# Altium schematic sheet Analog.SchDoc(Analog)
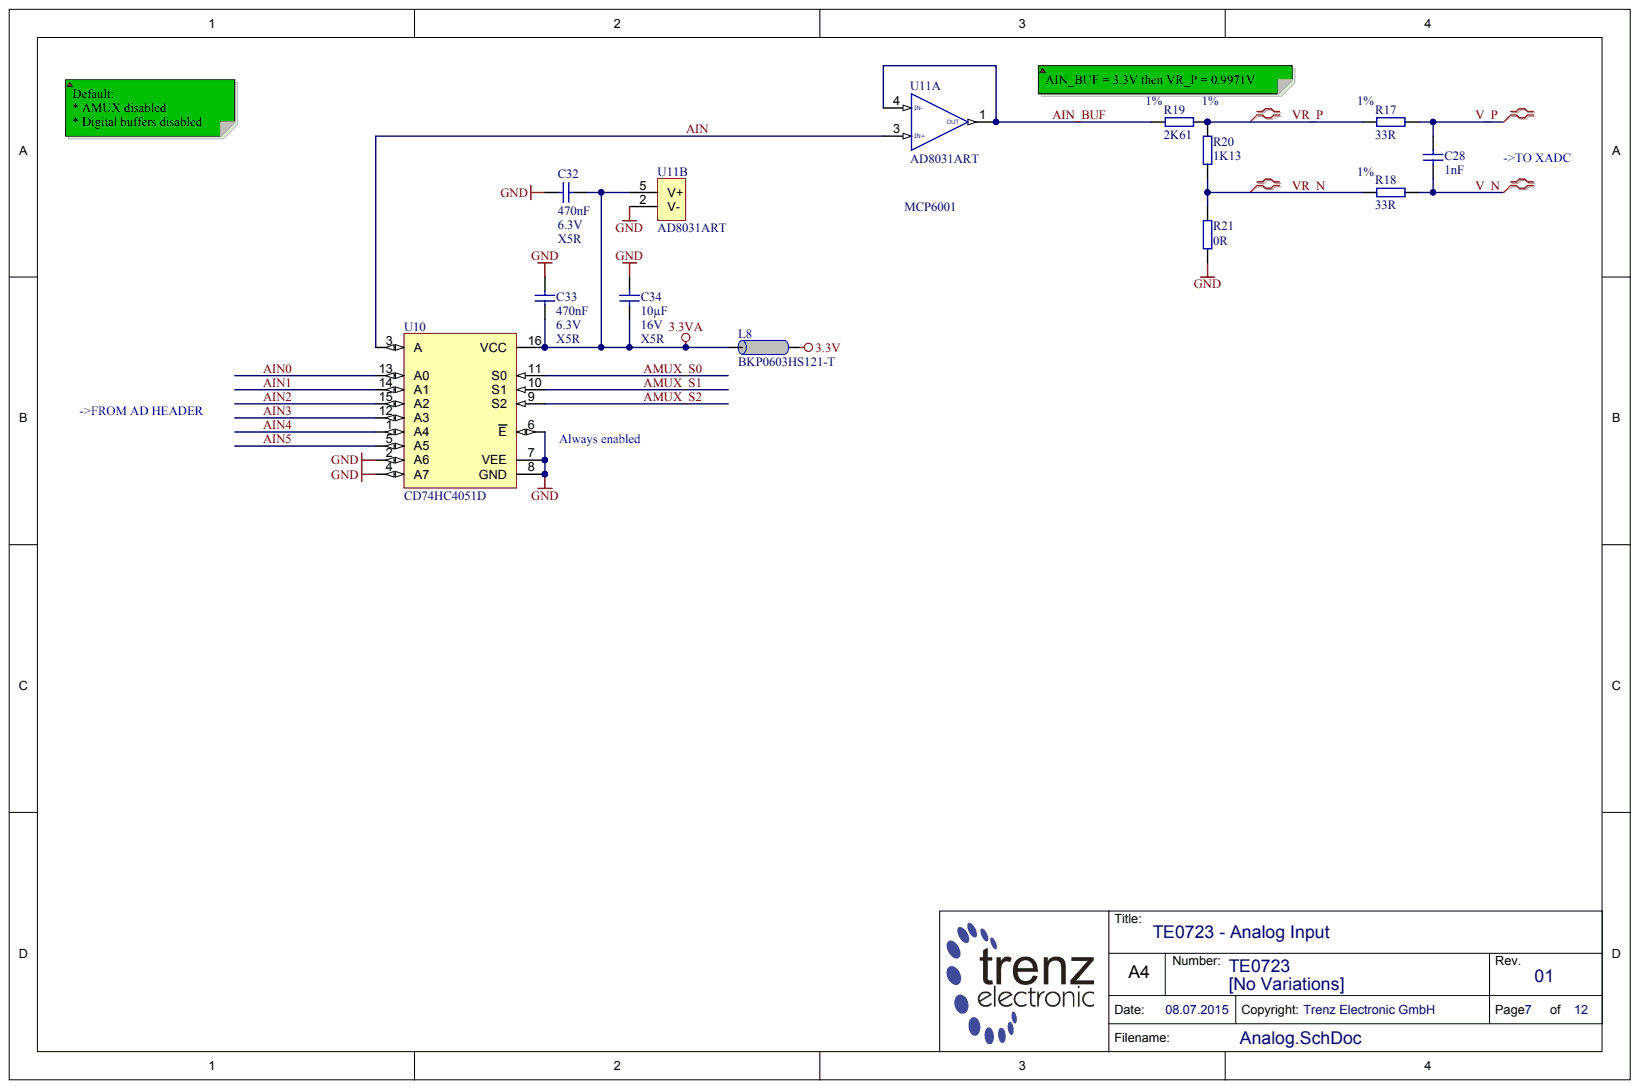
[diagram p0: Analog.SchDoc]

## Parts
C28  1nF  [CAPC1005X55N]
C32  470nF  Voltage: 6.3V  [CAPC0603X33N]
C33  470nF  Technology: X5R  Voltage: 6.3V  [CAPC0603X33N]
C34  10µF  Technology: X5R  Voltage: 16V  [CAPC1608X90N]
L8  BKP0603HS121-T  [INDC0603X33N]
R17  33R  Tolerance: 1%  [RESC0603X26N]
R18  33R  Tolerance: 1%  [RESC0603X26N]
R19  2K61  Tolerance: 1%  [RESC1005X40N]
R20  1K13  [RESC1005X40N]
R21  0R  [RESC1005X40N]
U10  CD74HC4051D  [SOIC127P600X175-16N]
U11  AD8031ART  [SOT95P280X145-5N]  (parts A,B)

## Nets
3.3V: L8.1
3.3VA: C32.2 C33.1 C34.2 L8.2 U10.16(VCC) U11.5(V+)
GND: C32.1 C33.2 C34.1 R21.2 U10.2(A6) U10.4(A7) U10.6(E) U10.7(VEE) U10.8(GND) U11.2(V-)
AIN: U10.3(A) U11.3(IN+)
AIN0: U10.13(A0)
AIN1: U10.14(A1)
AIN2: U10.15(A2)
AIN3: U10.12(A3)
AIN4: U10.1(A4)
AIN5: U10.5(A5)
AIN_BUF: R19.1 U11.1(OUT) U11.4(IN-)
AMUX_S0: U10.11(S0)
AMUX_S1: U10.10(S1)
AMUX_S2: U10.9(S2)
V_N: C28.2 R18.2
V_P: C28.1 R17.2
VR_N: R18.1 R20.2 R21.1
VR_P: R17.1 R19.2 R20.1

## Library data of the parts
C28 = 26184: KON 1nF 50V SMD AEC-Q200; Database Table Name: A_C_1005_0402; Mixed: SMT; Tolerance: 10%; Value: 1n; AN: 26184; Case: 1005 (0402); Component Kind: Standard; Library Name: TE.DbLib; Technology: X7R; Voltage: 50V
C32 C33 = 24921: KON 470nF X5R 6.3V SMD 20%; Database Table Name: C_0603_0201; Mixed: SMT; Preferred: Yes; Value: 470n; AN: 24921; Case: 0603 (0201); Component Kind: Standard; Library Name: TE.DbLib; Tolerance: 20%
  C32: Technology: X5R
C34 = 25341: KON 10µF 16V X5R SMD 20%; Database Table Name: C_1608_0603; Mixed: SMT; Preferred: Yes; Value: 10u; AN: 25341; Case: 1608 (0603); Component Kind: Standard; Library Name: TE.DbLib; Tolerance: 20%
L8 = 25393: Ferritdurchführung SMD 0201; Database Table Name: L_0603_0201; Mixed: SMT; Preferred: Yes; Tolerance: 25%; AN: 25393; Case: 0603 (0201); Component Kind: Standard; Library Name: TE.DbLib; Trenz-Stock: Yes; HelpURL: B:\AD\adlib\datasheet\MULTILAYER CHIP BEAD INDUCTORS BK
R17 R18 = 25021: WID SMD 33R 1% 0,05W; Database Table Name: R_0603_0201; Mixed: SMT; Preferred: Yes; Value: 33; AN: 25021; Case: 0603 (0201); Component Kind: Standard; Library Name: TE.DbLib; HelpURL: B:\AD\adlib\datasheet\R0201
R19 = 23730: WID SMD 2K61 1% 0,063W; Database Table Name: R_1005_0402; Mixed: SMT; Preferred: Yes; Value: 2.61K; AN: 23730; Case: 1005 (0402); Component Kind: Standard; Library Name: TE.DbLib
R20 = 23160: WID SMD 1K13 1% 0,063W; Database Table Name: R_1005_0402; Mixed: SMT; Preferred: Yes; Value: 1.13K; AN: 23160; Case: 1005 (0402); Component Kind: Standard; Library Name: TE.DbLib; Tolerance: 1%
R21 = 26222: WID SMD 0R 1% 0.063W AEC-Q200; Database Table Name: A_R_1005_0402; Mixed: SMT; Preferred: Yes; Value: 0; AN: 26222; Case: 1005 (0402); Component Kind: Standard; Library Name: TE.DbLib
U10 = 26538: Database Table Name: MUX; Mixed: SMT; Trenz-Stock: Yes; AN: 26538; Case: SOIC16 D (R-PDSO-G16); Component Kind: Standard; Description: CD74HC4051D; Library Name: TE.DbLib; HelpURL: B:\AD\adlib\datasheet\74HCx4051
U11 = 25377: Database Table Name: OP; Mixed: SMT; Trenz-Stock: Yes; AN: 25377; Case: SOT23-5; Component Kind: Standard; Description: AD8031ART; Library Name: TE.DbLib; HelpURL: B:\AD\adlib\datasheet\AD8031
